# Altium schematic sheet processingsys.SchDoc(processingsys)
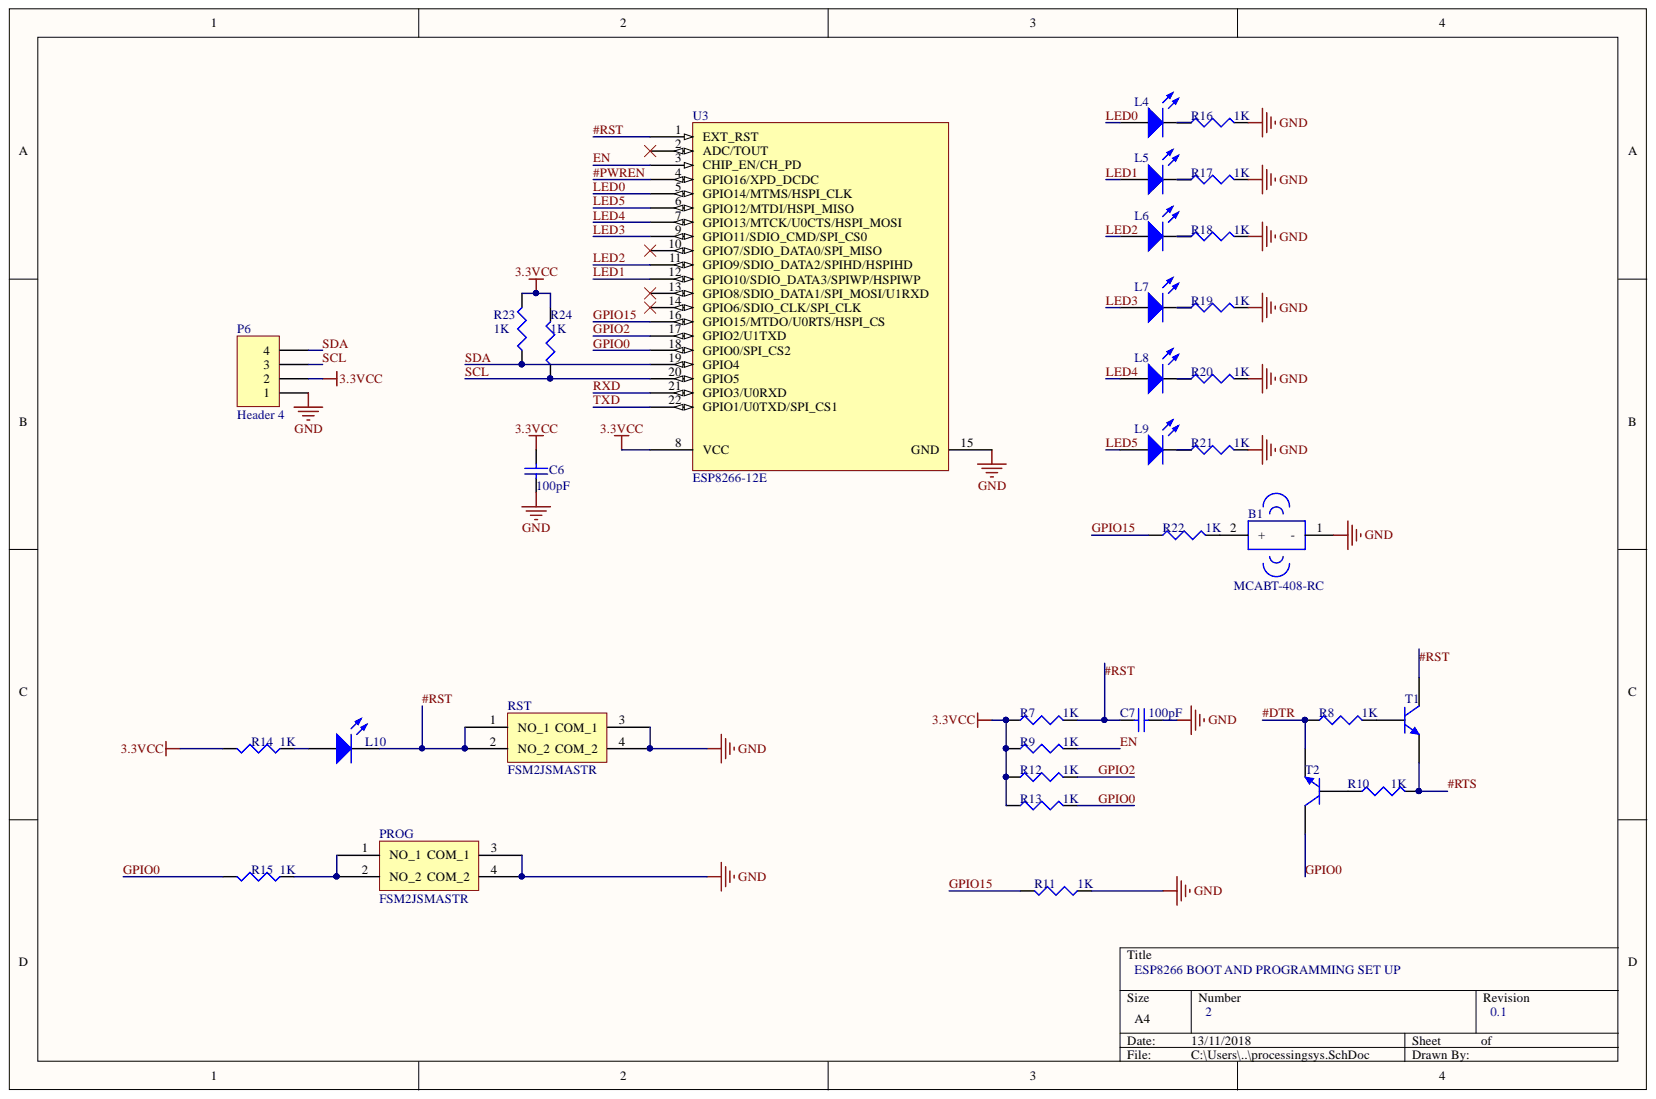
[diagram p0: processingsys.SchDoc]

## Parts
B1  MCABT-408-RC  [MCABT-408-RC]
C6  value 100pF  [C1206]  (hidden comment: Cap Semi)
C7  value 100pF  [C1206]  (hidden comment: Cap Semi)
L4  KM-23  [SOT-23]  (value not drawn)
L5  KM-23  [SOT-23]  (value not drawn)
L6  KM-23  [SOT-23]  (value not drawn)
L7  KM-23  [SOT-23]  (value not drawn)
L8  KM-23  [SOT-23]  (value not drawn)
L9  KM-23  [SOT-23]  (value not drawn)
L10  KM-23  [SOT-23]  (value not drawn)
P6  Header 4  [HDR1X4]
PROG  FSM2JSMASTR  [BUTTON_SMD]
R7  value 1K  [J1-0603]  (hidden comment: Res3)
R8  value 1K  [J1-0603]  (hidden comment: Res3)
R9  value 1K  [J1-0603]  (hidden comment: Res3)
R10  value 1K  [J1-0603]  (hidden comment: Res3)
R11  value 1K  [J1-0603]  (hidden comment: Res3)
R12  value 1K  [J1-0603]  (hidden comment: Res3)
R13  value 1K  [J1-0603]  (hidden comment: Res3)
R14  value 1K  [J1-0603]  (hidden comment: Res3)
R15  value 1K  [J1-0603]  (hidden comment: Res3)
R16  value 1K  [J1-0603]  (hidden comment: Res3)
R17  value 1K  [J1-0603]  (hidden comment: Res3)
R18  value 1K  [J1-0603]  (hidden comment: Res3)
R19  value 1K  [J1-0603]  (hidden comment: Res3)
R20  value 1K  [J1-0603]  (hidden comment: Res3)
R21  value 1K  [J1-0603]  (hidden comment: Res3)
R22  value 1K  [J1-0603]  (hidden comment: Res3)
R23  value 1K  [J1-0603]  (hidden comment: Res3)
R24  value 1K  [J1-0603]  (hidden comment: Res3)
RST  FSM2JSMASTR  [BUTTON_SMD]
T1  SBC846BWT1G  [SOT-323]  (value not drawn)
T2  SBC846BWT1G  [SOT-323]  (value not drawn)
U3  ESP8266-12E  [ESP8266-12E]

## Nets
3.3VCC: C6.2 P6.2 R7.1 R9.1 R12.1 R13.1 R14.2 R23.2 R24.2 U3.8(VCC)
GND: B1.1 C6.1 C7.1 P6.1 PROG.3(COM_1) PROG.4(COM_2) R11.1 R16.2 R17.2 R18.2 R19.2 R20.2 R21.2 RST.3(COM_1) RST.4(COM_2) U3.15(GND)
#DTR: R8.1 T2.2
#PWREN: U3.4(GPIO16/XPD_DCDC)
#RST: C7.2 L10.3 R7.2 RST.1(NO_1) RST.2(NO_2) T1.3 U3.1(EXT_RST)
#RTS: R10.2 T1.2
EN: R9.2 U3.3(CHIP_EN/CH_PD)
GPIO0: R13.2 R15.2 T2.3 U3.18(GPIO0/SPI_CS2)
GPIO2: R12.2 U3.17(GPIO2/U1TXD)
GPIO15: R11.2 R22.1 U3.16(GPIO15/MTDO/U0RTS/HSPI_CS)
LED0: L4.1 U3.5(GPIO14/MTMS/HSPI_CLK)
LED1: L5.1 U3.12(GPIO10/SDIO_DATA3/SPIWP/HSPIWP)
LED2: L6.1 U3.11(GPIO9/SDIO_DATA2/SPIHD/HSPIHD)
LED3: L7.1 U3.9(GPIO11/SDIO_CMD/SPI_CS0)
LED4: L8.1 U3.7(GPIO13/MTCK/U0CTS/HSPI_MOSI)
LED5: L9.1 U3.6(GPIO12/MTDI/HSPI_MISO)
RXD: U3.21(GPIO3/U0RXD)
SCL: P6.3 R24.1 U3.20(GPIO5)
SDA: P6.4 R23.1 U3.19(GPIO4)
TXD: U3.22(GPIO1/U0TXD/SPI_CS1)
NetB1_2: B1.2 R22.2
NetL4_3: L4.3 R16.1
NetL5_3: L5.3 R17.1
NetL6_3: L6.3 R18.1
NetL7_3: L7.3 R19.1
NetL8_3: L8.3 R20.1
NetL9_3: L9.3 R21.1
NetL10_1: L10.1 R14.1
NetPROG_1: PROG.1(NO_1) PROG.2(NO_2) R15.1
NetR8_2: R8.2 T1.1
NetR10_1: R10.1 T2.1

Not connected: U3 2(ADC/TOUT) 10(GPIO7/SDIO_DATA0/SPI_MISO) 13(GPIO8/SDIO_DATA1/SPI_MOSI/U1RXD) 14(GPIO6/SDIO_CLK/SPI_CLK)

## Library data of the parts
B1 = MCABT-408-RC: ransductor, 1 V a 3 V, 85 dBA, Sirena, 70 mA; Manufacturer: Multicomp; Self Resonant Frequency: 2.731kHz; Outside Diameter: 12mm; Min Supply Voltage: 1V; Max Supply Voltage: 3V; Current Rating: 70mA; Supplier 1: Farnell; Stock 1: 1057; REACH SVHC: Unknown; Pricing 1: 1=0.967, 5=0.876, 10=0.795, 20=0.738, 40=0.723, 100=0.708, 500=0.694 (EUR); Manufacturer Part Number: MCABT-408-RC; Height: 7.5mm; ComponentLink2URL: http://www.farnell.com/datasheets/1768519.pdf; ComponentLink2Description: http://www.farnell.com/datasheets/1768519.pdf; ComponentLink1URL: https://es.farnell.com/multicomp/mcabt-408-rc/ac-stico-electro-mech-audio-1/dp/2361105?krypto=Kzr7701dNdWkobLwazw2WAHmNHziskZrQMg7S%2Faf%2BaL%2F%2Bj%2FkWh1M3S1k%2FYSHSKSx0MzERa16wH8IxUPYeDKx2PmCEW9b7Qq2dWm4YJR83SHnond9nZgPFyrqEJusaoJIK60fC%2FoQdI36P39N2BQPzNsuueVDbqXwGAOKtHeDF30EVb%2FMeWA5wOoM2bNdaZFSgNjAKDp4mZigEnbiecpx7MGL6JOzzhP9BWUiYbys6ks5dt9LMNmTnpFtdjo7H2akCj%2F%2BfLNG71ArvMaoxZ0WMv4hKuovOAWfA3NHFC9HmqzoIwqKqKgSbKZowJ%2Btqn8YlCHLgv9T7vbfNqK3ZjJzUnVd%2FT%2BsNsbTS0FSkhssYu9EDD5ZGkWROWS0H93J6TpgHhXKvnZj%2BSUvRf0Y%2Bf3ZsPXOKqdIdoKAc%2FpA98XWUfDfcYNhjP%2Fdf3fuxTewHmiKE8rVjXfHvkQUwmS1KThmixSSWsU6BPdfoeHtQ48EBKM%3D&ddkey=https%3Aes-ES%2FElement14_Spain%2Fsearch; ComponentLink1Description: farnell; Component Kind: Standard; Library Name: ecubelib.SchLib
C6 C7 = Cap Semi: Capacitor (Semiconductor SIM Model); Simulation: CAP; Signal Integrity: Cap Semi; Published: 8-Jun-2000; PackageReference: C1206; PackageDescription: Chip Capacitor; Library Name: Miscellaneous Devices.IntLib; LatestRevisionNote: IPC-7351 Footprint Added.; LatestRevisionDate: 29-May-2009; Component Kind: Standard; Publisher: Altium Limited
L4-L10 = KM-23: Kingbright - LED, SOT-23; Manufacturer Part Number: KM-23ID-F; Wavelength: 625nm; Viewing Angle: 140°; Number of LEDs: 1; Luminous Intensity: 12mcd; Lens Style: Colored,Diffused,Rectangular; Lens Colour: Clear; Forward Voltage: 2V; Forward Current: 20mA; Colour: Red; Width: 1.2mm; Supplier 1: Farnell; Stock 1: 10839; REACH SVHC: No SVHC; Radiation Hardening: No; Pricing 1: 5=0.118, 25=0.112, 100=0.106, 250=0.101, 500=0.0968, 1000=0.0928 (GBP); Number of Pins: 3; Mount: Surface Mount; Manufacturer: Kingbright; Length: 2.8mm; Height: 1mm; Dominant Wavelength: 625nm; ComponentLink7URL: http://www.produktinfo.conrad.com/datenblaetter/125000-149999/144223-da-01-en-SMD_Leuchtdioden_KM23ID_Sot23_rot.pdf; ComponentLink7Description: http://www.produktinfo.conrad.com/datenblaetter/125000-149999/144223-da-01-en-SMD_Leuchtdioden_KM23ID_Sot23_rot.pdf; ComponentLink6URL: http://www.produktinfo.conrad.com/datenblaetter/175000-199999/180090-da-01-en-LED_SOT23_TYP_KM_23ID_F.pdf; ComponentLink6Description: http://www.produktinfo.conrad.com/datenblaetter/175000-199999/180090-da-01-en-LED_SOT23_TYP_KM_23ID_F.pdf; ComponentLink5URL: http://uk.rs-online.com/webdocs/032f/0900766b8032f166.pdf; ComponentLink5Description: http://uk.rs-online.com/webdocs/032f/0900766b8032f166.pdf; ComponentLink4URL: https://www.distrelec.biz/Web/Downloads/d_/en/cuKingbright_SOT-23_SMT-LED_EN.pdf?mime=application%2Fpdf; ComponentLink4Description: https://www.distrelec.biz/Web/Downloads/d_/en/cuKingbright_SOT-23_SMT-LED_EN.pdf?mime=application%2Fpdf; ComponentLink3URL: http://www.farnell.com/datasheets/1563685.pdf; ComponentLink3Description: http://www.farnell.com/datasheets/1563685.pdf; ComponentLink2URL: https://es.farnell.com/kingbright/km-23sgd-f/led-green-sot-23-smd/dp/2099217?st=KM-23; ComponentLink2Description: farnell-Green; ComponentLink1URL: https://es.farnell.com/kingbright/km-23id-f/led-red-sot-23-smd/dp/2099216?st=KM-23ID; ComponentLink1Description: farnell -RED; Component Kind: Standard; Case/Package: SOT-23; Library Name: ecubelib.SchLib
P6 = Header 4: Header, 4-Pin; Signal Integrity: Connector; Library Name: Miscellaneous Connectors.IntLib; LatestRevisionNote: Re-released for DXP Platform.; LatestRevisionDate: 17-Jul-2002; Component Kind: Standard; Publisher: Altium Limited
PROG RST = FSM2JSMASTR: TE Connectivity - Interruptor Táctil, Montaje Superficial (SMD); Manufacturer Part Number: 1977223-4; Voltage Rating (DC): 24V; Throw Configuration: SPST; Operating Force: 1.57N; Mechanical Life: 1000000Cycles; Max Current Rating: 50mA; Actuator Material: Nylon; Actuator Length: 0.7mm; Type: Switch; Termination: Gull Wing; Supplier 1: Farnell; Stock 1: 1514; Radiation Hardening: No; Pricing 1: 5=0.184, 100=0.179, 250=0.173, 1000=0.168, 2500=0.162 (EUR); Packaging: Tape and Reel; Orientation: Straight; Mount: Surface Mount; Min Operating Temperature: -40°C; Max Voltage Rating (DC): 24V; Max Operating Temperature: 105°C; Manufacturer: TE Connectivity; Length: 6mm; ELV: Compliant; Depth: 10mm; Contact Plating: Silver; Contact Material: Copper Alloy; Contact Current Rating: 50mA; ComponentLink2URL: http://www.te.com/commerce/DocumentDelivery/DDEController?Action=srchrtrv&DocNm=1308111-1_SWITCHES_CORE_PROGRAM_CATALOG&DocType=Catalog+Section&DocLang=English; ComponentLink2Description: http://www.te.com/commerce/DocumentDelivery/DDEController?Action=srchrtrv&DocNm=1308111-1_SWITCHES_CORE_PROGRAM_CATALOG&DocType=Catalog+Section&DocLang=English; ComponentLink1URL: https://es.farnell.com/alcoswitch-te-connectivity/fsm2jsmastr/interruptor-t-ctil-spst-0-05a/dp/2610941?krypto=STQKwvVYAPccPvWo2qKFm%2B2gHuVF7WkaqIiu7Dm0cJ5iCIeV7DHhPViRfCOgoFsJStXtod%2BnIQ%2BYkMVEvW6iM3AuzhepTn6uOw1UVwc7VB8KUrNLl0uQiR%2Bt35da8c9%2BQU171xU6PFtEc8EnsSVD6zTrAoZNeFTntAPThe1lSw75SwgbO5cQ33JndyglwNSD%2Fmpse8Gtvc2qmuIyzAgV9svHZkncDZZX6ZXbcKP216NPBEjbrtNYbKi2SeLDw4XeR8vtbf5NJKVxMUwbBhIrG2GTfYXybkb4t0M%2BFUX2Mjpm2Pqiy7%2FaHyLXsF%2F6JqWeJ%2FtTnNjkvf4o7u918wSV9RxDYtXvBiLcNJGrHbUuu6%2BAwcACzT8Mk6xWTk1NrmLNmfrigBPTmYlqdrRkSyqTCN5s9fVyopuyy4jhDrGN6PxrQet12eg7oAfq92u%2FGproWwHxsaTab23LGvFEnuMkIb5ATL7w%2Fr2VOeHmcwkXp7E%3D&ddkey=https%3Aes-ES%2FElement14_Spain%2Fsearch; ComponentLink1Description: farnell; Component Kind: Standard; Case/Package: SMD/SMT; Library Name: ecubelib.SchLib
R7-R24 = Res3: Resistor; Simulation: RESISTOR; Signal Integrity: Res; Published: 8-Jun-2000; PackageReference: J1-0603; PackageDescription: Chip Resistor; Library Name: Miscellaneous Devices.IntLib; LatestRevisionNote: IPC-7351 Footprint Added.; LatestRevisionDate: 29-May-2009; Component Kind: Standard; Publisher: Altium Limited
T1 T2 = SBC846BWT1G: ON SEMICONDUCTOR - SBC846BWT1G - TRANSISTOR, BIPOL, NPN, 65V; Transition Frequency: 100MHz; Power Dissipation: 150mW; Number of Elements: 1; Max Collector Current: 100mA; Emitter Base Voltage (VEBO): 6V; Element Configuration: Single; Collector Emitter Saturation Voltage: 600mV; Collector Base Voltage (VCBO): 80V; Supplier 1: Farnell; Stock 1: 0; REACH SVHC: No SVHC; Radiation Hardening: No; Pricing 1: 150=0.0932, 250=0.0803, 500=0.0675, 1000=0.0545, 5000=0.0456 (EUR); Polarity: NPN; Packaging: Tape and Reel; Package Quantity: 3000; Number of Pins: 3; Min Operating Temperature: -55°C; Max Power Dissipation: 150mW; Max Operating Temperature: 150°C; Max Breakdown Voltage: 65V; Manufacturer Part Number: SBC846BWT1G; Manufacturer: ON Semiconductor; Lead Free: Lead Free; Halogen Free: Halogen Free; Gain Bandwidth Product: 100MHz; Frequency: 100MHz; ComponentLink3URL: http://www.onsemi.com/pub/Collateral/BC846AWT1-D.PDF; ComponentLink3Description: http://www.onsemi.com/pub/Collateral/BC846AWT1-D.PDF; ComponentLink2URL: http://www.mouser.com/ds/2/308/BC846AWT1-D-103558.pdf; ComponentLink2Description: http://www.mouser.com/ds/2/308/BC846AWT1-D-103558.pdf; ComponentLink1URL: https://es.farnell.com/on-semiconductor/sbc846bwt1g/transistor-bipolar-npn-65-v/dp/2533346?st=SBC846BWT1G; ComponentLink1Description: farnell; Component Kind: Standard; Collector Emitter Voltage (VCEO): 65V; Collector Emitter Breakdown Voltage: 65V; Case/Package: SC; Library Name: ecubelib.SchLib
U3 = ESP8266-12E: Manufacturer Part Number: 2491; Manufacturer: Adafruit Industries; Sensitivity: -91dBm; Interface: I2C,SPI,UART; Frequency: 2.4GHz; Data Rate: 72.2Mbps; Current - Transmitting: 170mA; Current - Receiving: 56mA; Supplier 1: Mouser; Stock 1: 0; Packaging: Bulk; Mount: Surface Mount; Min Operating Temperature: -40°C; Max Operating Temperature: 125°C; Component Kind: Standard; Case/Package: Module; Library Name: ecubelib.SchLib
